# Altium schematic sheet A-Headers.SchDoc(A-Headers)
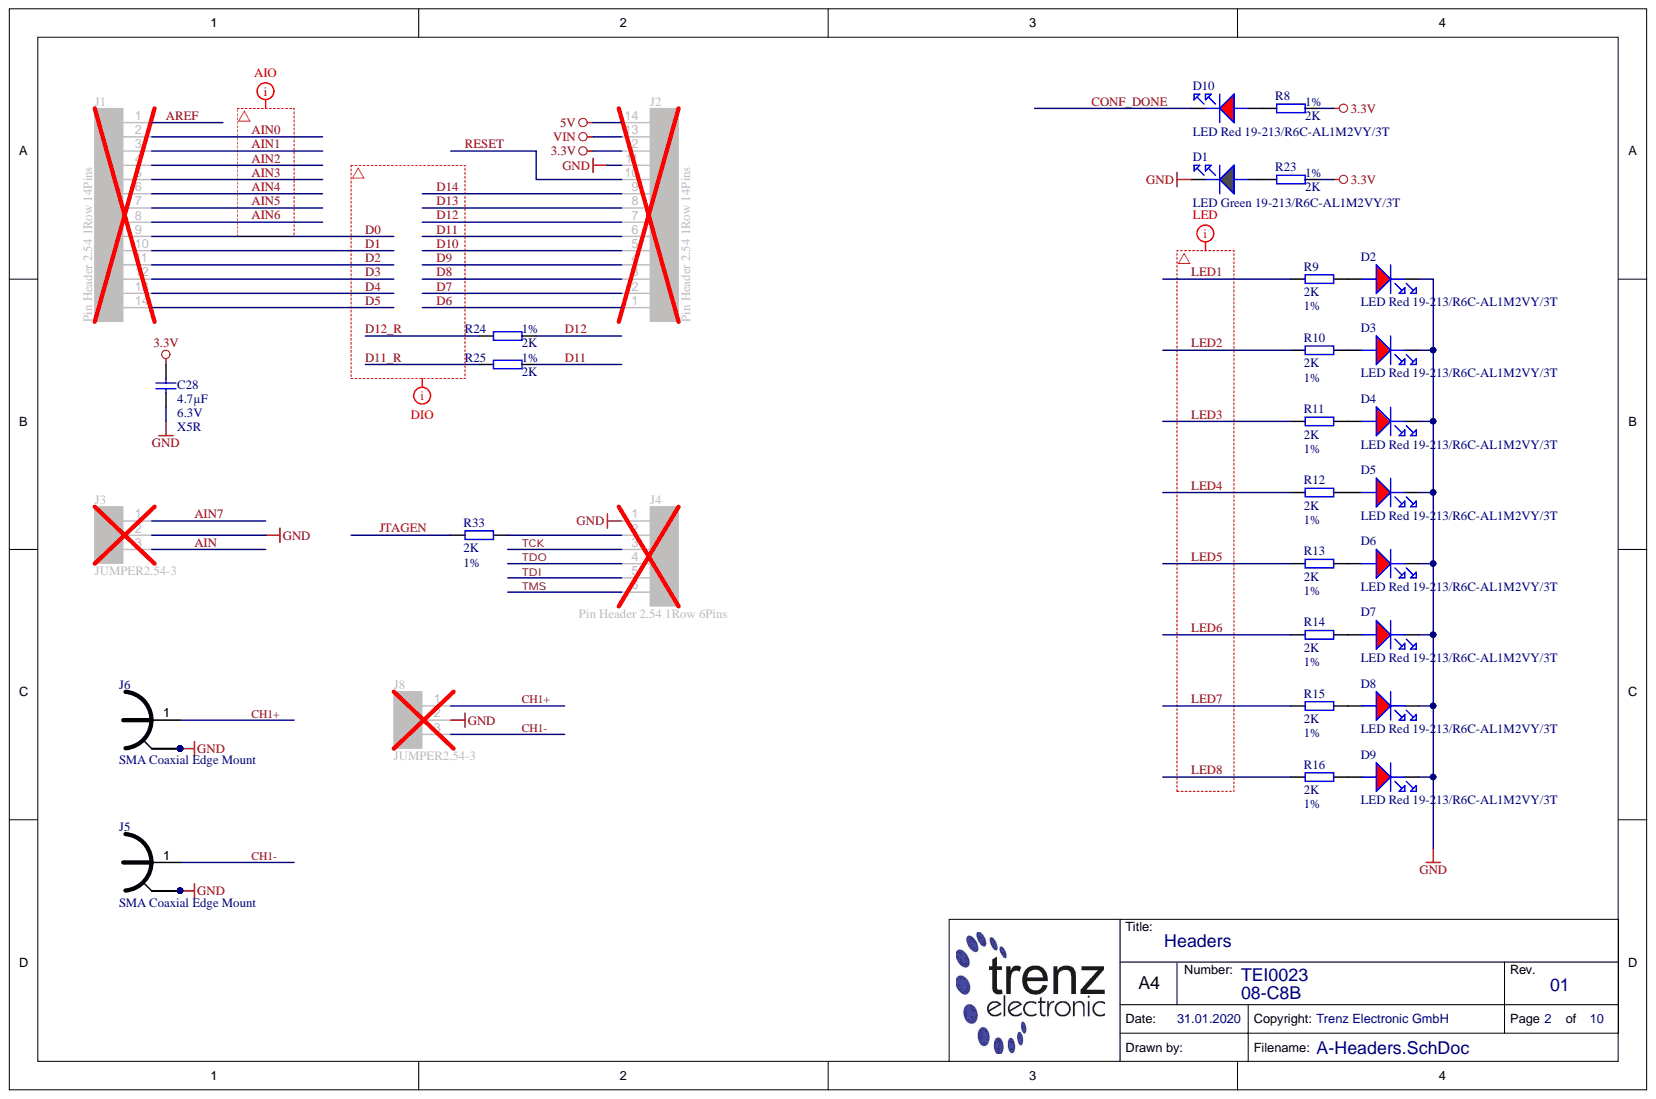
[diagram p0: A-Headers.SchDoc]

## Parts
C28  4.7µF  Voltage: 6.3V  Technology: X5R  [CAPC1005X60N]
D1  LED Green 19-213/R6C-AL1M2VY/3T  Database Table Name: LED  [LEDC1608X55N_GREEN]
D2  LED Red 19-213/R6C-AL1M2VY/3T  H-ART: 19-213/R6C-AL1M2VY/3T  Database Table Name: LED  [LEDC1608X55N_RED]
D3  LED Red 19-213/R6C-AL1M2VY/3T  H-ART: 19-213/R6C-AL1M2VY/3T  Database Table Name: LED  [LEDC1608X55N_RED]
D4  LED Red 19-213/R6C-AL1M2VY/3T  H-ART: 19-213/R6C-AL1M2VY/3T  Database Table Name: LED  [LEDC1608X55N_RED]
D5  LED Red 19-213/R6C-AL1M2VY/3T  H-ART: 19-213/R6C-AL1M2VY/3T  Database Table Name: LED  [LEDC1608X55N_RED]
D6  LED Red 19-213/R6C-AL1M2VY/3T  H-ART: 19-213/R6C-AL1M2VY/3T  Database Table Name: LED  [LEDC1608X55N_RED]
D7  LED Red 19-213/R6C-AL1M2VY/3T  H-ART: 19-213/R6C-AL1M2VY/3T  Database Table Name: LED  [LEDC1608X55N_RED]
D8  LED Red 19-213/R6C-AL1M2VY/3T  H-ART: 19-213/R6C-AL1M2VY/3T  Database Table Name: LED  [LEDC1608X55N_RED]
D9  LED Red 19-213/R6C-AL1M2VY/3T  H-ART: 19-213/R6C-AL1M2VY/3T  Database Table Name: LED  [LEDC1608X55N_RED]
D10  LED Red 19-213/R6C-AL1M2VY/3T  H-ART: 19-213/R6C-AL1M2VY/3T  Database Table Name: LED  [LEDC1608X55N_RED]
J1  Pin Header 2.54 1Row 14Pins  [STIFTLEISTE2_54 OG 1R-14]
J2  Pin Header 2.54 1Row 14Pins  [STIFTLEISTE2_54 OG 1R-14]
J3  JUMPER2.54-3  [STIFTLEISTE2_54 OG 1R-3]
J4  Pin Header 2.54 1Row 6Pins  [STIFTLEISTE2_54 OG 1R-6]
J5  SMA Coaxial Edge Mount  [SMA-J-P-X-ST-EM1]
J6  SMA Coaxial Edge Mount  [SMA-J-P-X-ST-EM1]
J8  JUMPER2.54-3  [STIFTLEISTE2_54 OG 1R-3]
R8  2K  Tolerance: 1%  [RESC1005X40N]
R9  2K  Tolerance: 1%  [RESC1005X40N]
R10  2K  Tolerance: 1%  [RESC1005X40N]
R11  2K  Tolerance: 1%  [RESC1005X40N]
R12  2K  Tolerance: 1%  [RESC1005X40N]
R13  2K  Tolerance: 1%  [RESC1005X40N]
R14  2K  Tolerance: 1%  [RESC1005X40N]
R15  2K  Tolerance: 1%  [RESC1005X40N]
R16  2K  Tolerance: 1%  [RESC1005X40N]
R23  2K  Tolerance: 1%  [RESC1005X40N]
R24  2K  Tolerance: 1%  [RESC1005X40N]
R25  2K  Tolerance: 1%  [RESC1005X40N]
R33  2K  Tolerance: 1%  [RESC1005X40N]

## Nets
3.3V: C28.1 J2.12 R8.2 R23.2
5V: J2.14
GND: C28.2 D1.K D2.K D3.K D4.K D5.K D6.K D7.K D8.K D9.K J2.11 J3.2 J4.1 J5.2 J5.3 J5.4 J5.5 J6.2 J6.3 J6.4 J6.5 J8.2
VIN: J2.13
AIN: J3.3
AIN0: J1.2
AIN1: J1.3
AIN2: J1.4
AIN3: J1.5
AIN4: J1.6
AIN5: J1.7
AIN6: J1.8
AIN7: J3.1
AREF: J1.1
CH1+: J6.1 J8.1
CH1-: J5.1 J8.3
CONF_DONE: D10.K
D0: J1.9
D1: J1.10
D2: J1.11
D3: J1.12
D4: J1.13
D5: J1.14
D6: J2.1
D7: J2.2
D8: J2.3
D9: J2.4
D10: J2.5
D11: J2.6 R25.1
D11_R: R25.2
D12: J2.7 R24.1
D12_R: R24.2
D13: J2.8
D14: J2.9
JTAGEN: R33.1
LED1: R9.1
LED2: R10.1
LED3: R11.1
LED4: R12.1
LED5: R13.1
LED6: R14.1
LED7: R15.1
LED8: R16.1
RESET: J2.10
TCK: J4.3
TDI: J4.5
TDO: J4.4
TMS: J4.6
NetD1_A: D1.A R23.1
NetD2_A: D2.A R9.2
NetD3_A: D3.A R10.2
NetD4_A: D4.A R11.2
NetD5_A: D5.A R12.2
NetD6_A: D6.A R13.2
NetD7_A: D7.A R14.2
NetD8_A: D8.A R15.2
NetD9_A: D9.A R16.2
NetD10_A: D10.A R8.1
NetJ4_2: J4.2 R33.2
N00054:
N00055:
N00056:

## Library data of the parts
C28 = 24719: KON 4.7µF 6.3V X5R 20% SMD BF 1005 (0402); Value: 4.7u; Temperature-Range: -55/+85; Preferred: Yes; Mixed: SMT; Database Table Name: C_1005_0402; Tolerance: 20%; Library Name: TE.DbLib; Component Kind: Standard; Case: 1005 (0402); AN: 24719
D1 = 28262: Chip LED 0603 Briliant Green; Temperature-Range: -40/+85 (Abs); Mixed: SMT; H-ART: 19-213/G6C-BM1N2/DT; Library Name: TE.DbLib; Component Kind: Standard; Case: 1608 (0603); AN: 28262; HelpURL: b:\AD\adlib\datasheet\19-213-G6C-BM1\
D2-D10 = 28261: Chip LED 0603 Briliant Red; Mixed: SMT; Library Name: TE.DbLib; Component Kind: Standard; Case: 1608 (0603); AN: 28261; HelpURL: b:\AD\adlib\datasheet\19-213-R6C\
J1 J2 = 27961: Stiftleiste gerade 1-reihig, 14pol.; Mixed: THT; Database Table Name: CON_HEADER; Library Name: TE.DbLib; Component Kind: Standard; AN: 27961; HelpURL: b:\AD\adlib\datasheet\W+P_943_944_945\
J3 J8 = 27653: Stiftleiste gerade 1-reihig, 3pol.; Trenz-Article-Number: 22328; Mixed: THT; Database Table Name: CON_HEADER; Library Name: TE.DbLib; Component Kind: Standard; AN: 27653; HelpURL: b:\AD\adlib\datasheet\W+P_943_944_945\
J4 = 28042: Stiftleiste gerade 1-reihig, 6pol; Mixed: THT; Database Table Name: CON_HEADER; Library Name: TE.DbLib; Component Kind: Standard; AN: 28042
J5 J6 = 29678: SMA Coaxial 90° SMT Edge Mount; Trenz-Stock: Yes; Temperature-Range: -65/+125; Mixed: THT; H-ART: SMA-J-P-H-ST-EM1; Database Table Name: CON_IO; Library Name: TE.DbLib; Component Kind: Standard; Case: Edge mount; AN: 29678; HelpURL: b:\AD\adlib\datasheet\Samtec_SMA\
R8-R16 R23-R25 R33 = 25073: WID SMD 2K 1% 0,063W  BF 1005 (0402); Preferred: Yes; Mixed: SMT; H-ART: RC0402FR-072KL; Database Table Name: R_1005_0402; Value: 2K; Technology: TK100; Library Name: TE.DbLib; Component Kind: Standard; Case: 1005 (0402); AN: 25073
